# Altium schematic sheet BusPirate.SchDoc
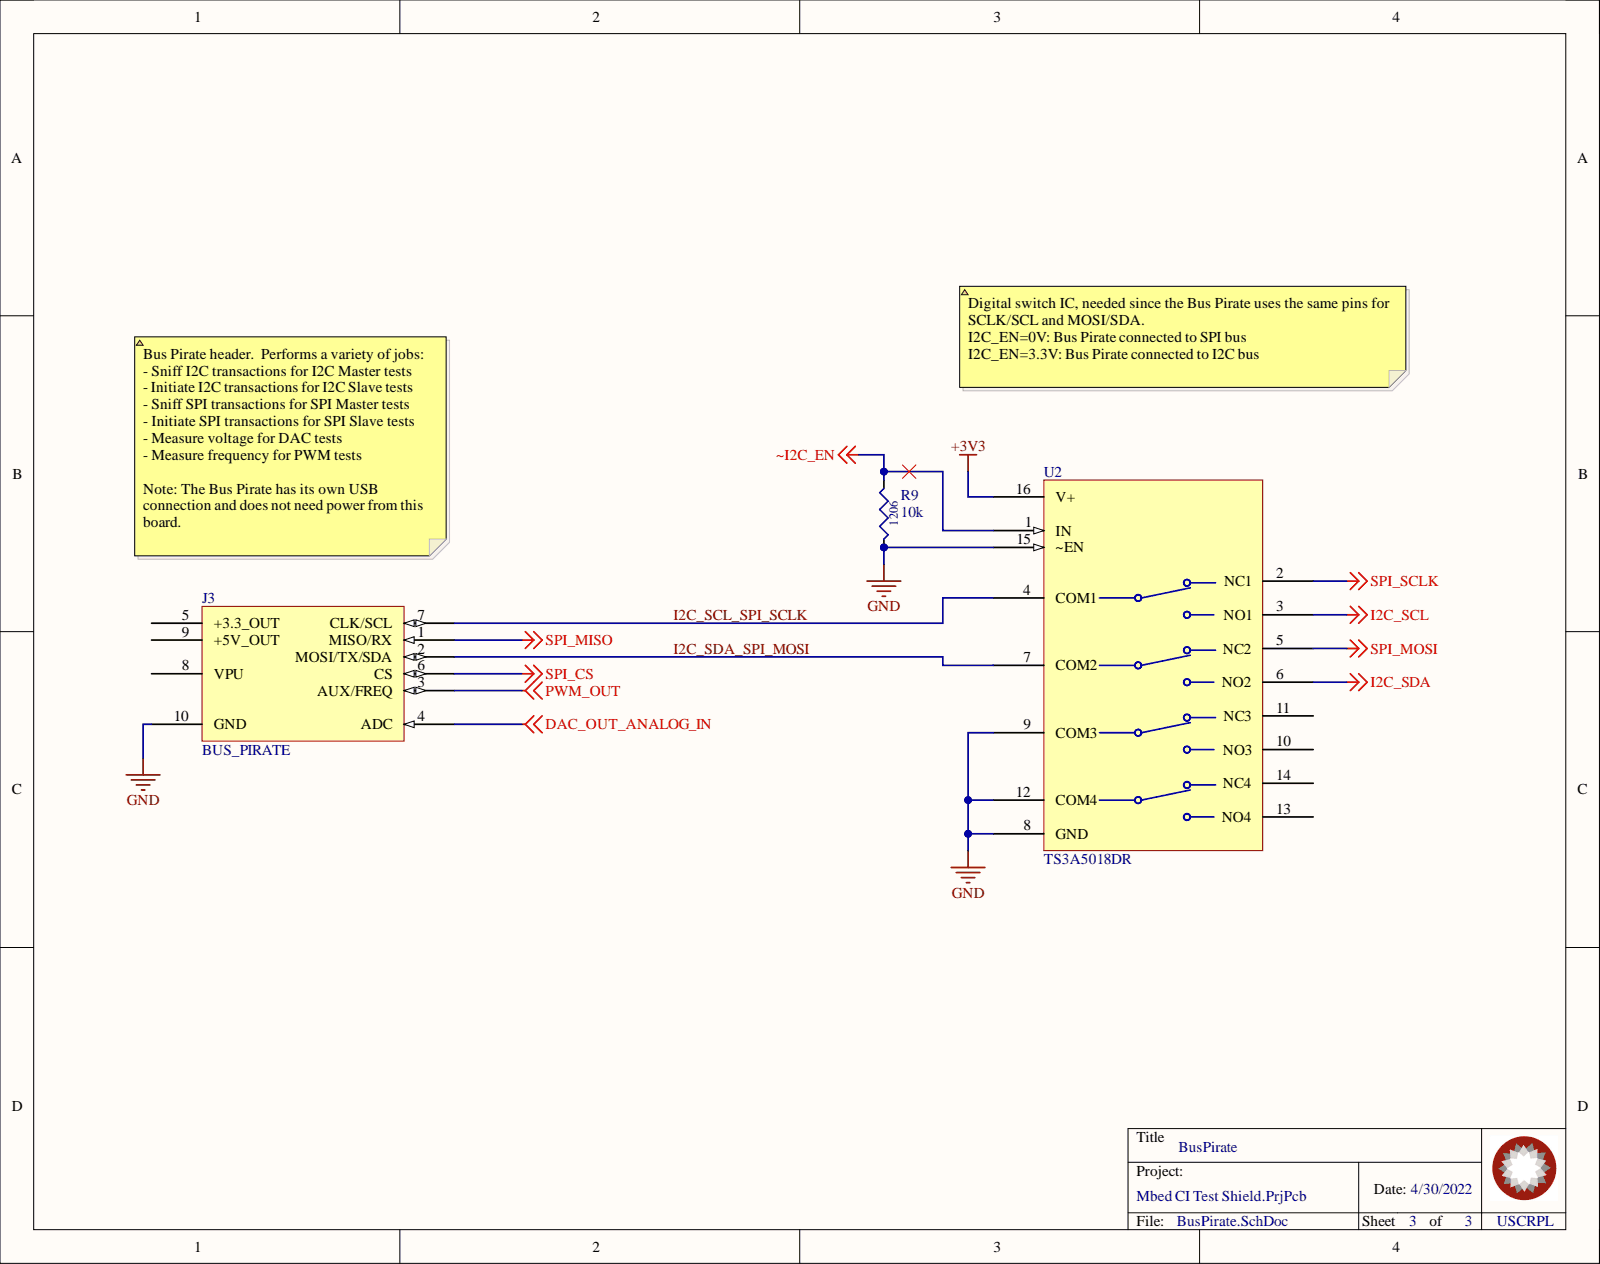
[diagram p0: BusPirate.SchDoc]

## Parts
J3  BUS_PIRATE  [SFH11-PBPC-D05-ST-BK-Footprint-2]
R9  10k  [R1206]
U2  TS3A5018DR  [SOIC127P600-8N]

## Nets
+3V3: U2.16(V+)
DAC_OUT_ANALOG_IN: J3.4(ADC)
GND: J3.10(GND) R9.1 U2.8(GND) U2.9(COM3) U2.12(COM4) U2.15(~EN)
I2C_SCL: U2.3(NO1)
I2C_SDA: U2.6(NO2)
PWM_OUT: J3.3(AUX/FREQ)
SPI_CS: J3.6(CS)
SPI_MISO: J3.1(MISO/RX)
SPI_MOSI: U2.5(NC2)
SPI_SCLK: U2.2(NC1)
~I2C_EN: R9.2 U2.1(IN)
I2C_SCL_SPI_SCLK: J3.7(CLK/SCL) U2.4(COM1)
I2C_SDA_SPI_MOSI: J3.2(MOSI/TX/SDA) U2.7(COM2)

Not connected: J3 5(+3.3_OUT) 8(VPU) 9(+5V_OUT); U2 10(NO3) 11(NC3) 13(NO4) 14(NC4)

## Library data of the parts
J3 = CMP-002-00005-3: Bus Pirate Header Connector; Made By: Jamie Smith; Component Kind: Standard; Design Item ID: BUS_PIRATE; Supplier 1: Digi-Key; Supplier Part Number 1: S9194-ND; Library Name: USCRPL
R9 = CMP-024-000034-3: 10K OHM 1% 1/4W 1206; Made By: Brennan Swanton; Reviewed By: Carter Allen; Component Kind: Standard; Design Item ID: ERJ-8ENF1002V; Source: Resistors.SchLib; Supplier 1: Digi-Key; Supplier Part Number 1: 541-2247-1-ND; Library Name: USCRPL
U2 = CMP-037-000011-3: Analog Switch 4ch 10Ohm 100MHz; Made By: Jamie Smith; Component Kind: Standard; Design Item ID: TS3A5018DR; Supplier 1: Digi-Key; Supplier Part Number 1: 296-17611-1-ND; Library Name: USCRPL
